# Altium schematic sheet Power.SchDoc(Power)
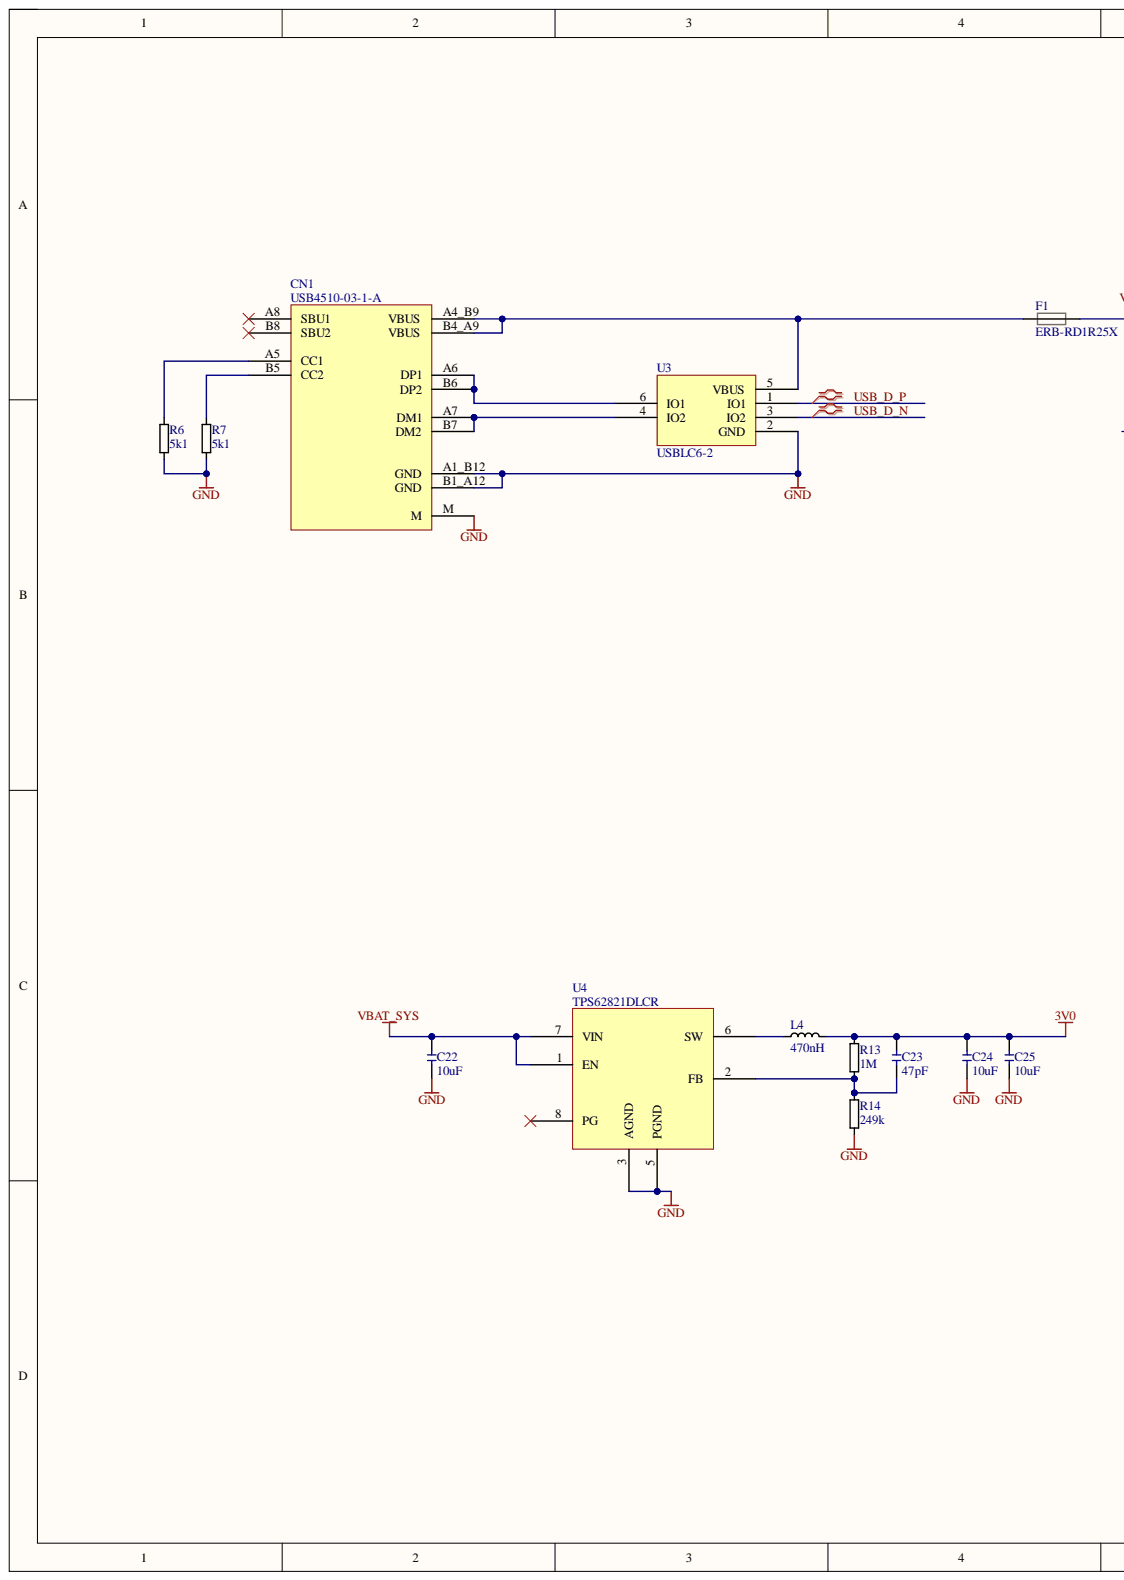
[diagram p0: Power.SchDoc, left]
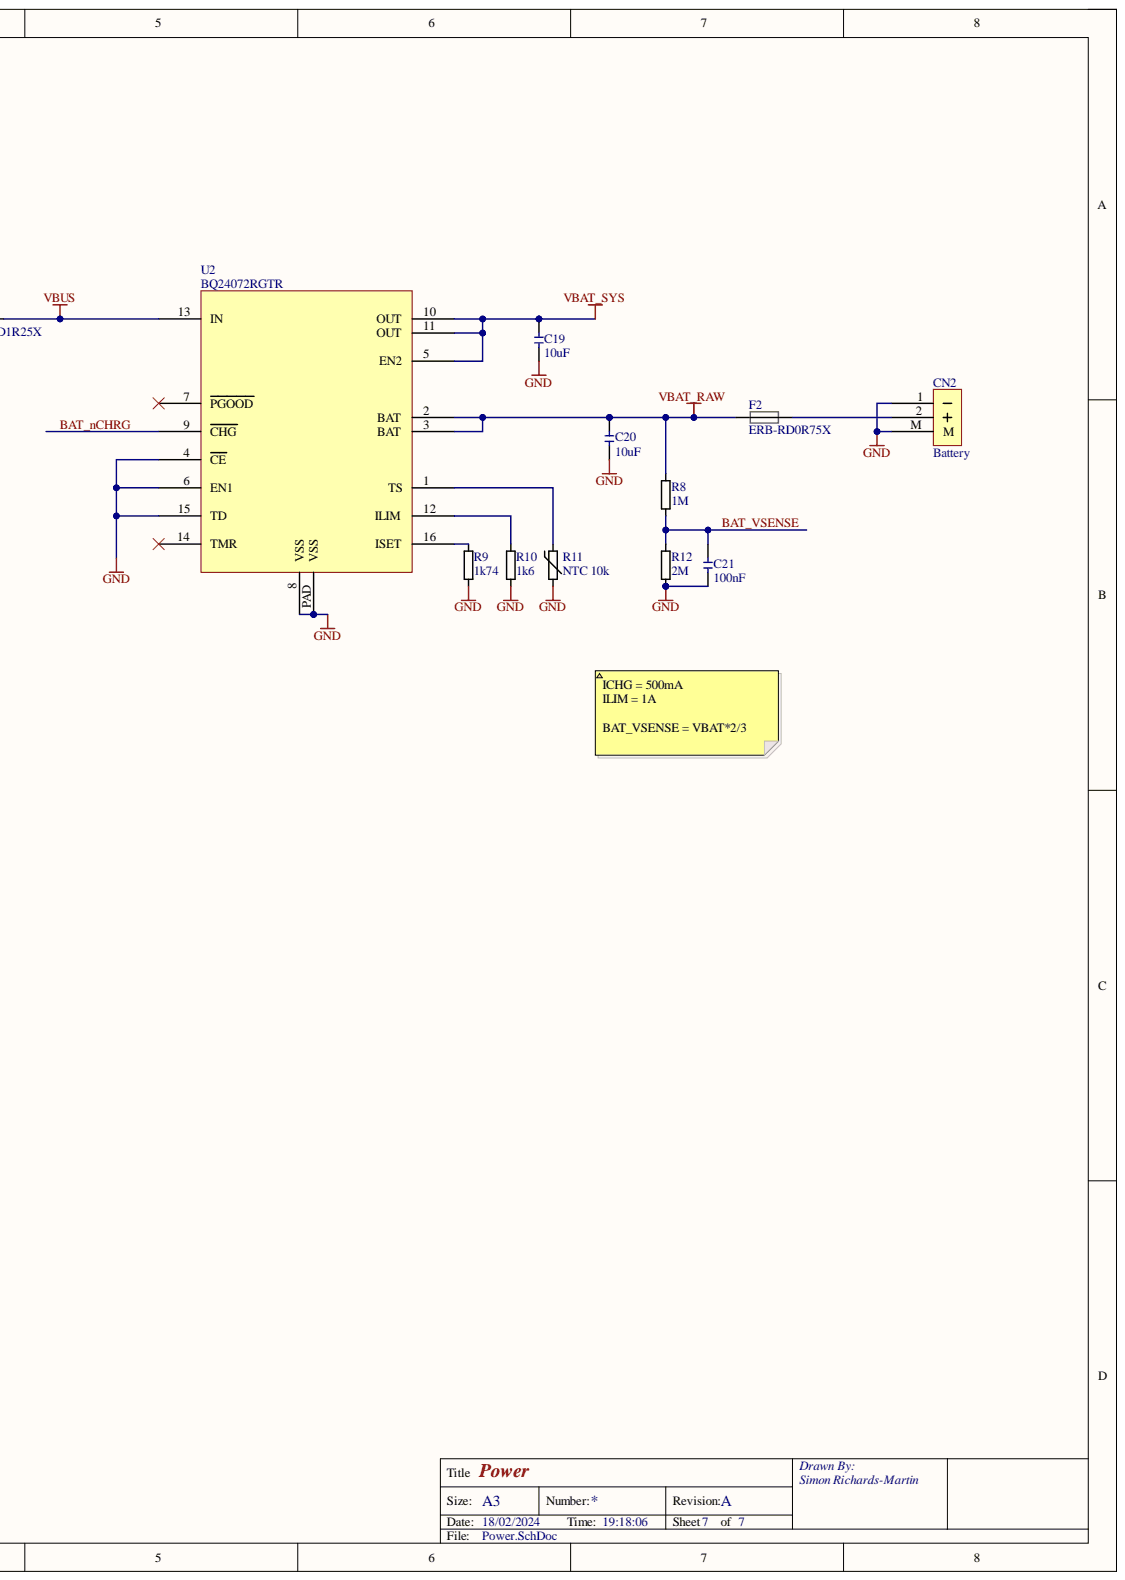
[diagram p1: Power.SchDoc, right]

## Parts
C19  10uF  [CHIP-0402]
C20  10uF  [CHIP-0402]
C21  100nF  [CHIP-0402]
C22  10uF  [CHIP-0402]
C23  47pF  [CHIP-0402]
C24  10uF  [CHIP-0402]
C25  10uF  [CHIP-0402]
CN1  USB4510-03-1-A  [USB4510-03-1-A]
CN2  Battery  [MOLEX 53261-0271]
F1  ERB-RD1R25X  [CHIP-0402]
F2  ERB-RD0R75X  [CHIP-0402]
L4  470nH  [INDC2520X10N]
R6  5k1  [CHIP-0402]
R7  5k1  [CHIP-0402]
R8  1M  [CHIP-0402]
R9  1k74  [CHIP-0402]
R10  1k6  [CHIP-0402]
R11  NTC 10k  [CHIP-0402]
R12  2M  [CHIP-0402]
R13  1M  [CHIP-0402]
R14  249k  [CHIP-0402]
U2  BQ24072RGTR  [QFN50P300X300X100_HS-17N]
U3  USBLC6-2  [SOT23-6]
U4  TPS62821DLCR  [SON50P150X100-8L]

## Nets
3V0: C23.1 C24.1 C25.1 L4.1 R13.2
GND: C19.2 C20.2 C21.2 C22.2 C24.2 C25.2 CN1.A1_B12(GND) CN1.B1_A12(GND) CN1.M CN2.1 CN2.M R6.1 R7.1 R9.1 R10.1 R11.2 R12.1 R14.1 U2.4(CE) U2.6(EN1) U2.8(VSS) U2.15(TD) U2.PAD(VSS) U3.2(GND) U4.3(AGND) U4.5(PGND)
VBAT_RAW: C20.1 F2.2 R8.2 U2.2(BAT) U2.3(BAT)
VBAT_SYS: C19.1 C22.1 U2.5(EN2) U2.10(OUT) U2.11(OUT) U4.1(EN) U4.7(VIN)
VBUS: F1.1 U2.13(IN)
BAT_nCHRG: U2.9(CHG)
BAT_VSENSE: C21.1 R8.1 R12.2
USB_D_N: U3.3(IO2)
USB_D_P: U3.1(IO1)
NetC23_2: C23.2 R13.1 R14.2 U4.2(FB)
NetCN1_A4_B9: CN1.A4_B9(VBUS) CN1.B4_A9(VBUS) F1.2 U3.5(VBUS)
NetCN1_A5: CN1.A5(CC1) R6.2
NetCN1_A6: CN1.A6(DP1) CN1.B6(DP2) U3.6(IO1)
NetCN1_A7: CN1.A7(DM1) CN1.B7(DM2) U3.4(IO2)
NetCN1_B5: CN1.B5(CC2) R7.2
NetCN2_2: CN2.2 F2.1
NetL4_2: L4.2 U4.6(SW)
NetR9_2: R9.2 U2.16(ISET)
NetR10_2: R10.2 U2.12(ILIM)
NetR11_1: R11.1 U2.1(TS)

Not connected: CN1 A8(SBU1) B8(SBU2); U2 7(PGOOD) 14(TMR); U4 8(PG)

## Library data of the parts
C19 C20 C22 C24 C25 = Capacitor 10uF 10V 0402 X5R: Manufacturer: Murata; MPN: GRM155R61A106ME11D; Component Kind: Standard; Description: Capacitor 10uF 10V 0402 X5R; Library Name: Paramotor Sensor Main.SchLib
C21 = Capacitor 100nF 50V 0402 X5R: Manufacturer: Murata; MPN: GRM155R61H104KE14D; Component Kind: Standard; Description: Capacitor 100nF 50V 0402 X5R; Library Name: Paramotor Sensor Main.SchLib
C23 = Capacitor 47pF 25V 0402 C0G: Manufacturer: Murata; MPN: GRM1555C1E470JA01D; Component Kind: Standard; Description: Capacitor 47pF 25V 0402 C0G; Library Name: Paramotor Sensor Main.SchLib
CN1 = USB4510-03-1-A: USB-C RA Mid Mount 16Pin; Manufacturer: GCT; Component Kind: Standard; MPN: USB4510-03-1-A; Library Name: Paramotor Sensor Main.SchLib
CN2 = Molex Picoblade 2-way RA SMT: Manufacturer: Molex; MPN: 53261-7002; Component Kind: Standard; Library Name: Paramotor Sensor Main.SchLib
F1 = ERB-RD1R25X: Fuse SMT 1.25A 32VDC 0402; Manufacturer: Panasonic; Component Kind: Standard; MPN: ERB-RD1R25X; Library Name: Paramotor Sensor Main.SchLib
F2 = ERB-RD0R75X: Fuse SMT 750mA 32VDC 0402; Manufacturer: Panasonic; Component Kind: Standard; MPN: ERB-RD0R75X; Library Name: Paramotor Sensor Main.SchLib
L4 = SRP2510A-R47M: Inductor Shielded 0.47uH 5A 2510; Manufacturer: Bourns; Component Kind: Standard; MPN: SRP2510A-R47M; Library Name: Paramotor Sensor Main.SchLib
R6 R7 = Resistor 5.1k 1% 0402: Manufacturer: Yageo; MPN: AC0402FR-075K1L; Component Kind: Standard; Description: Resistor 5.1k 1% 0402; Library Name: Paramotor Sensor Main.SchLib
R8 R13 = Resistor 1M 1% 0402: Manufacturer: Bourns; MPN: CR0402-FX-1004GLF; Component Kind: Standard; Description: Resistor 1M 1% 0402; Library Name: Paramotor Sensor Main.SchLib
R9 = Resistor 1.74k 1% 0402: Manufacturer: Yageo; MPN: RC0402FR-071K74L; Component Kind: Standard; Description: Resistor 1.74k 1% 0402; Library Name: Paramotor Sensor Main.SchLib
R10 = Resistor 1.6k 1% 0402: Manufacturer: Yageo; MPN: RC0402FR-071K6L; Component Kind: Standard; Description: Resistor 1.6k 1% 0402; Library Name: Paramotor Sensor Main.SchLib
R11 = Thermistor NTC 10k 0402: Thermistor NTC 10k B=3435K 0402; Manufacturer: TDK; MPN: NTCG103JF103FT1; Component Kind: Standard; Library Name: Paramotor Sensor Main.SchLib
R12 = Resistor 2M 1% 0402: Manufacturer: Yageo; MPN: RC0402FR-072ML; Component Kind: Standard; Description: Resistor 2M 1% 0402; Library Name: Paramotor Sensor Main.SchLib
R14 = Resistor 249k 1% 0402: Manufacturer: Yageo; MPN: AC0402FR-07249KL; Component Kind: Standard; Description: Resistor 249k 1% 0402; Library Name: Paramotor Sensor Main.SchLib
U2 = (no symbol name): Sgl cell Li-ion charger 4.2V 1.5A VQFN-16; Manufacturer: Texas Instruments; Component Kind: Standard; MPN: BQ24072RGTR; Library Name: Paramotor Sensor Main.SchLib
U3 = USBLC6-2: TVS Specialised Low-Cap USB SOT26; Manufacturer: ST Microelectronics; MPN: USBLC6-2SC6; Component Kind: Standard; Library Name: Paramotor Sensor Main.SchLib
U4 = TPS62821DLCR: Buck Regulator 1A 2.2MHz 4uA IQ VSON-HR-8; Manufacturer: Texas Instruments; Component Kind: Standard; MPN: TPS62821DLCR; Library Name: Paramotor Sensor Main.SchLib
